# Altium schematic sheet Sheet1.SchDoc
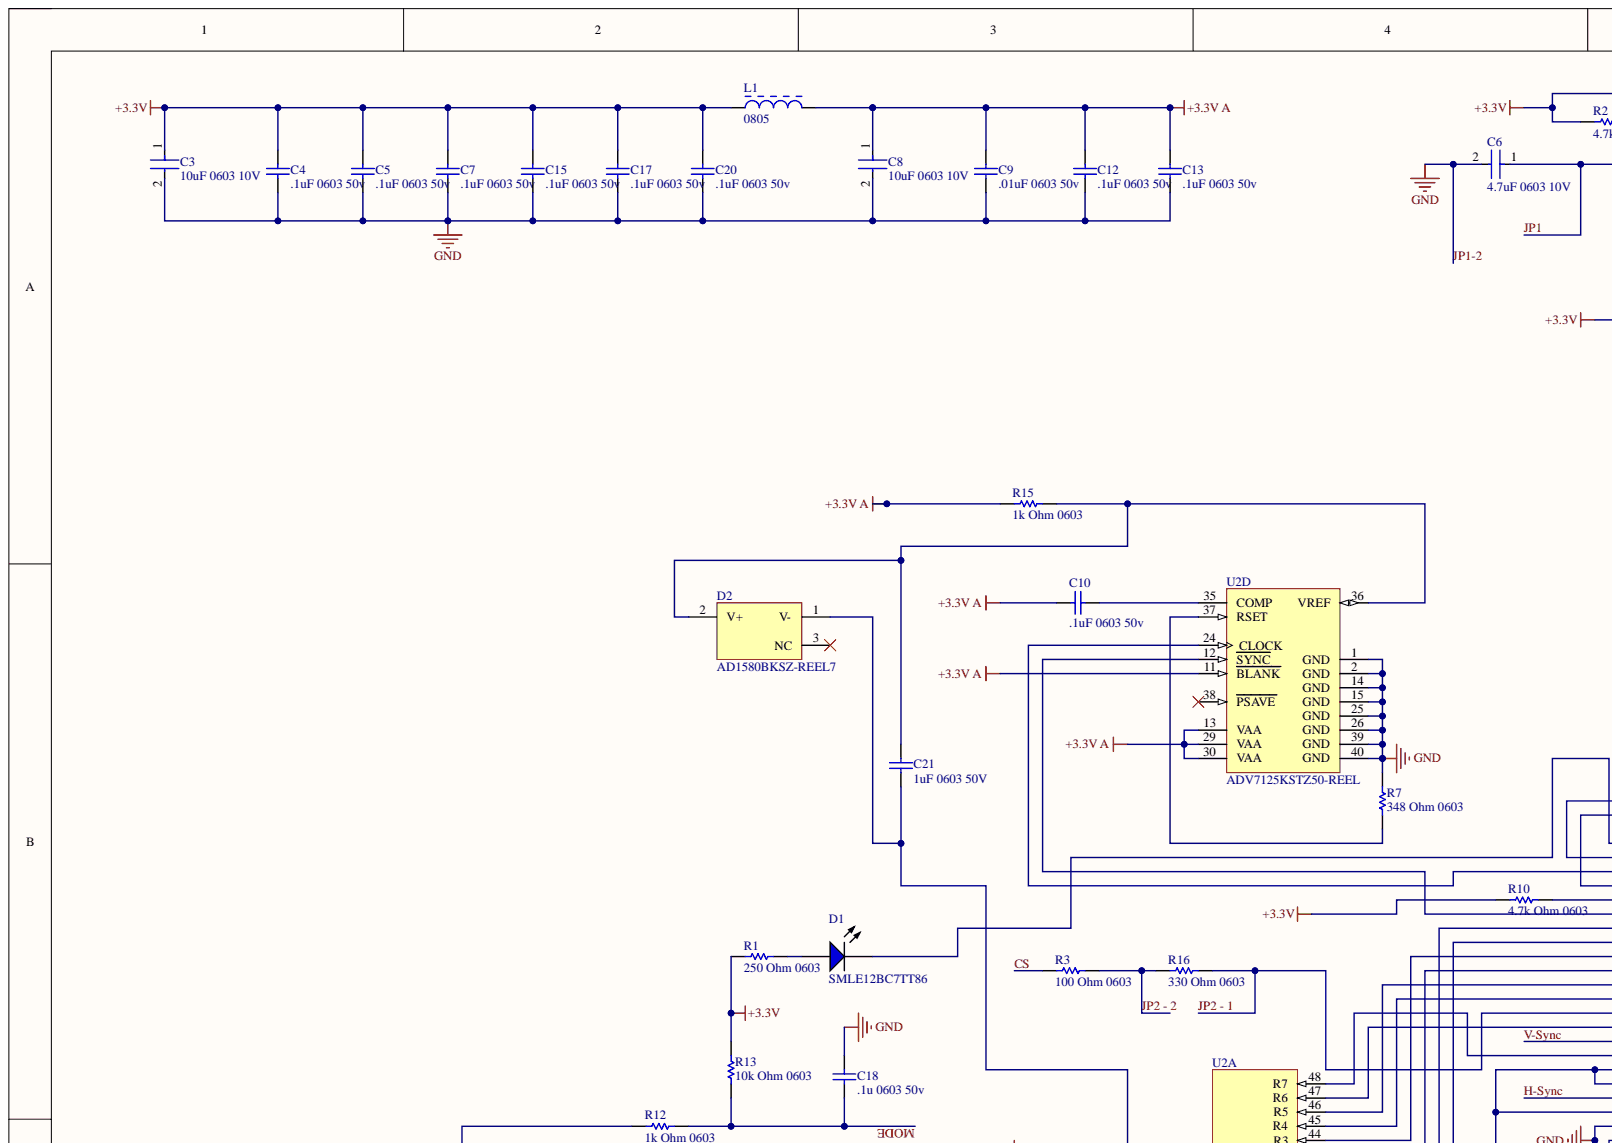
[diagram p0: Sheet1.SchDoc, top left]
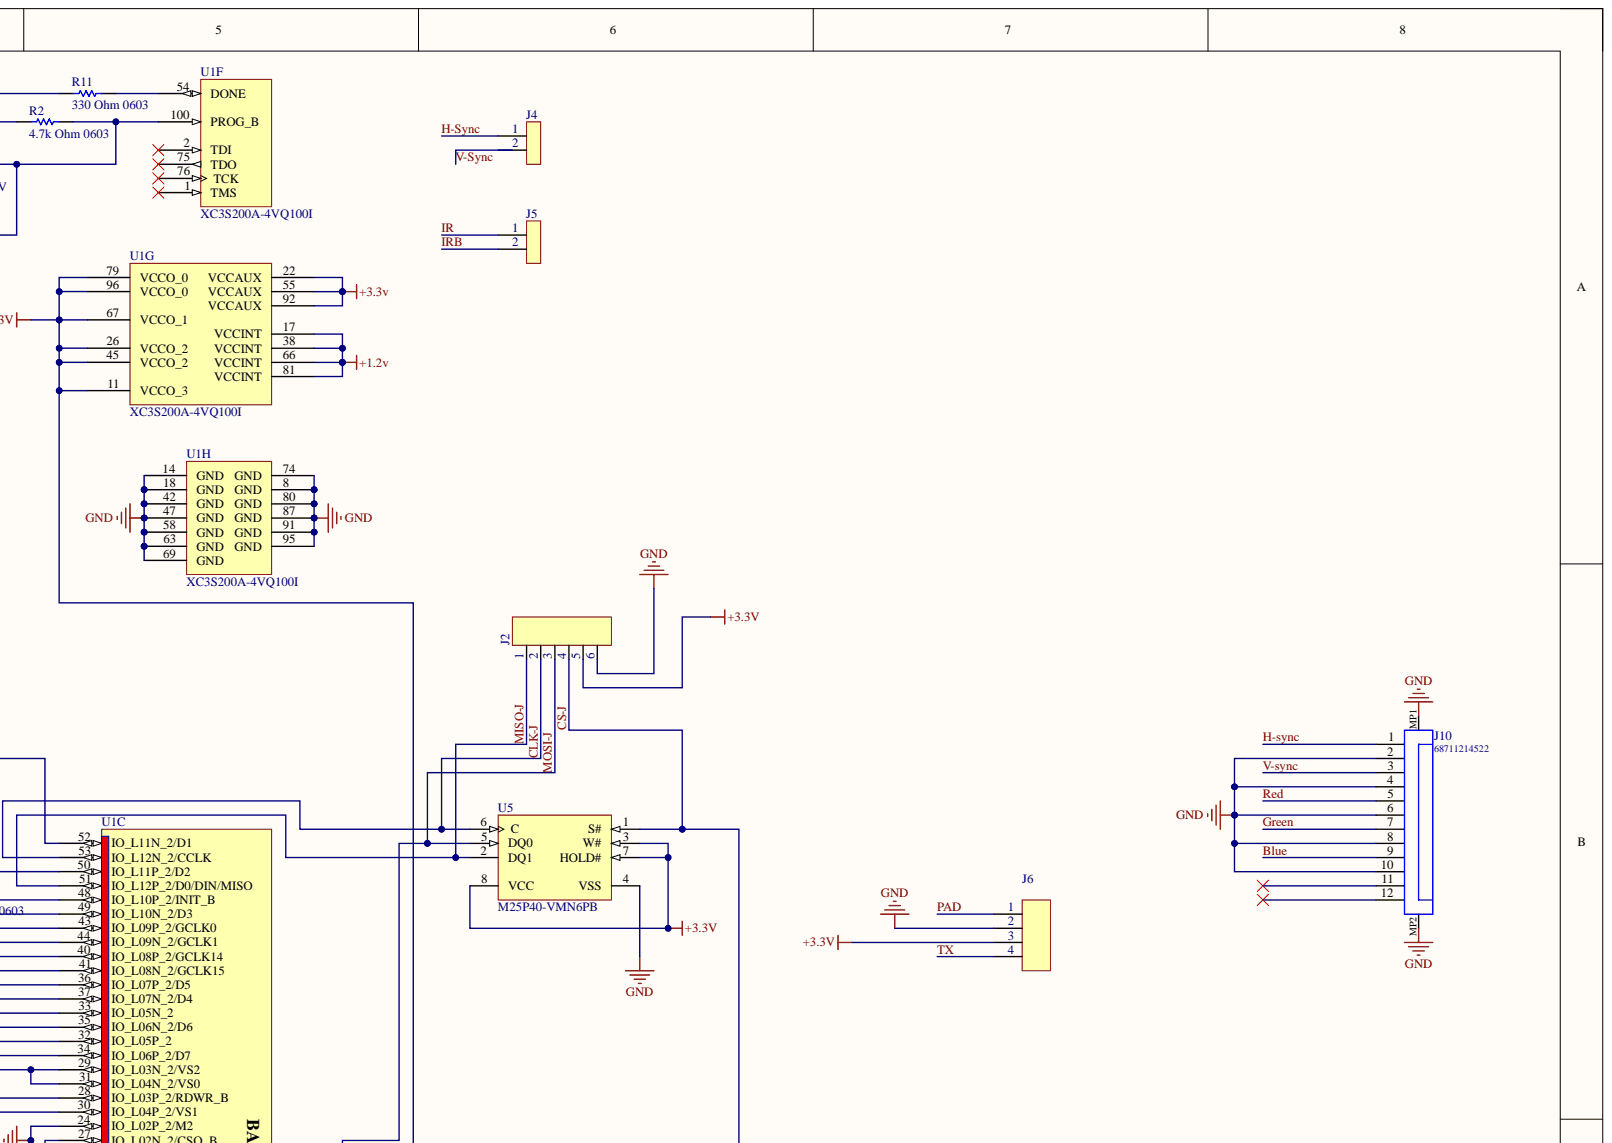
[diagram p1: Sheet1.SchDoc, top right]
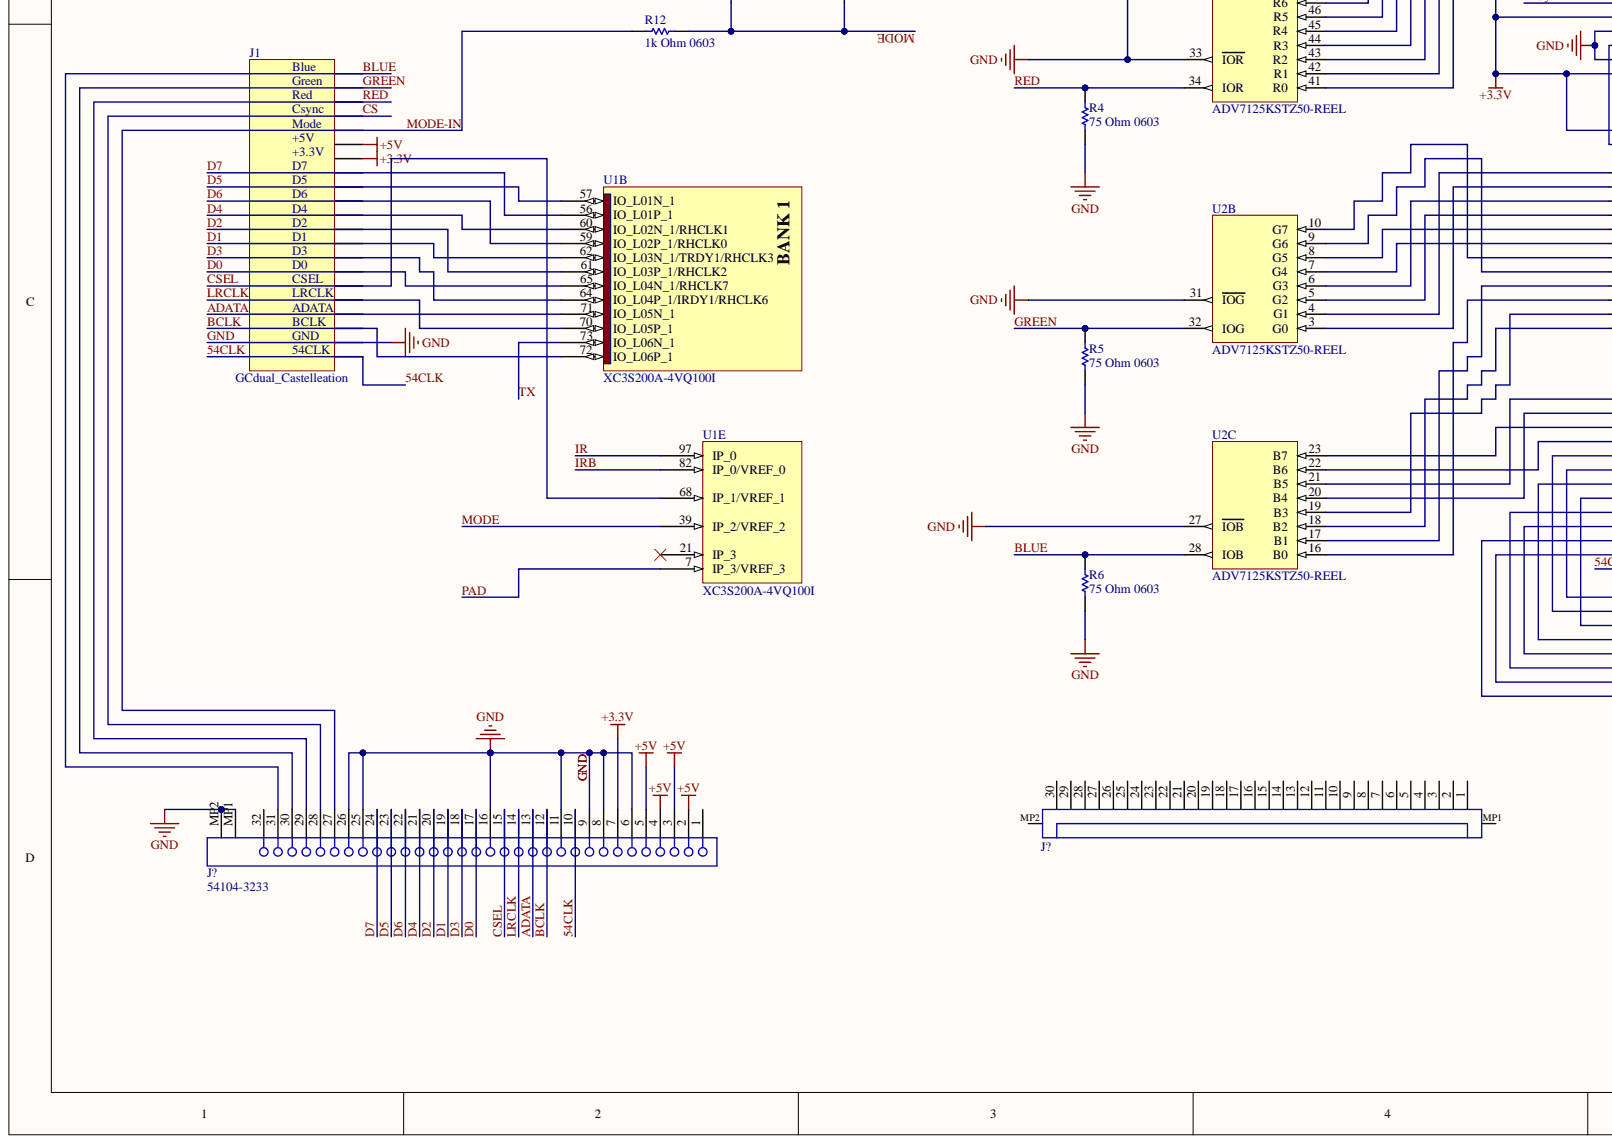
[diagram p2: Sheet1.SchDoc, bottom left]
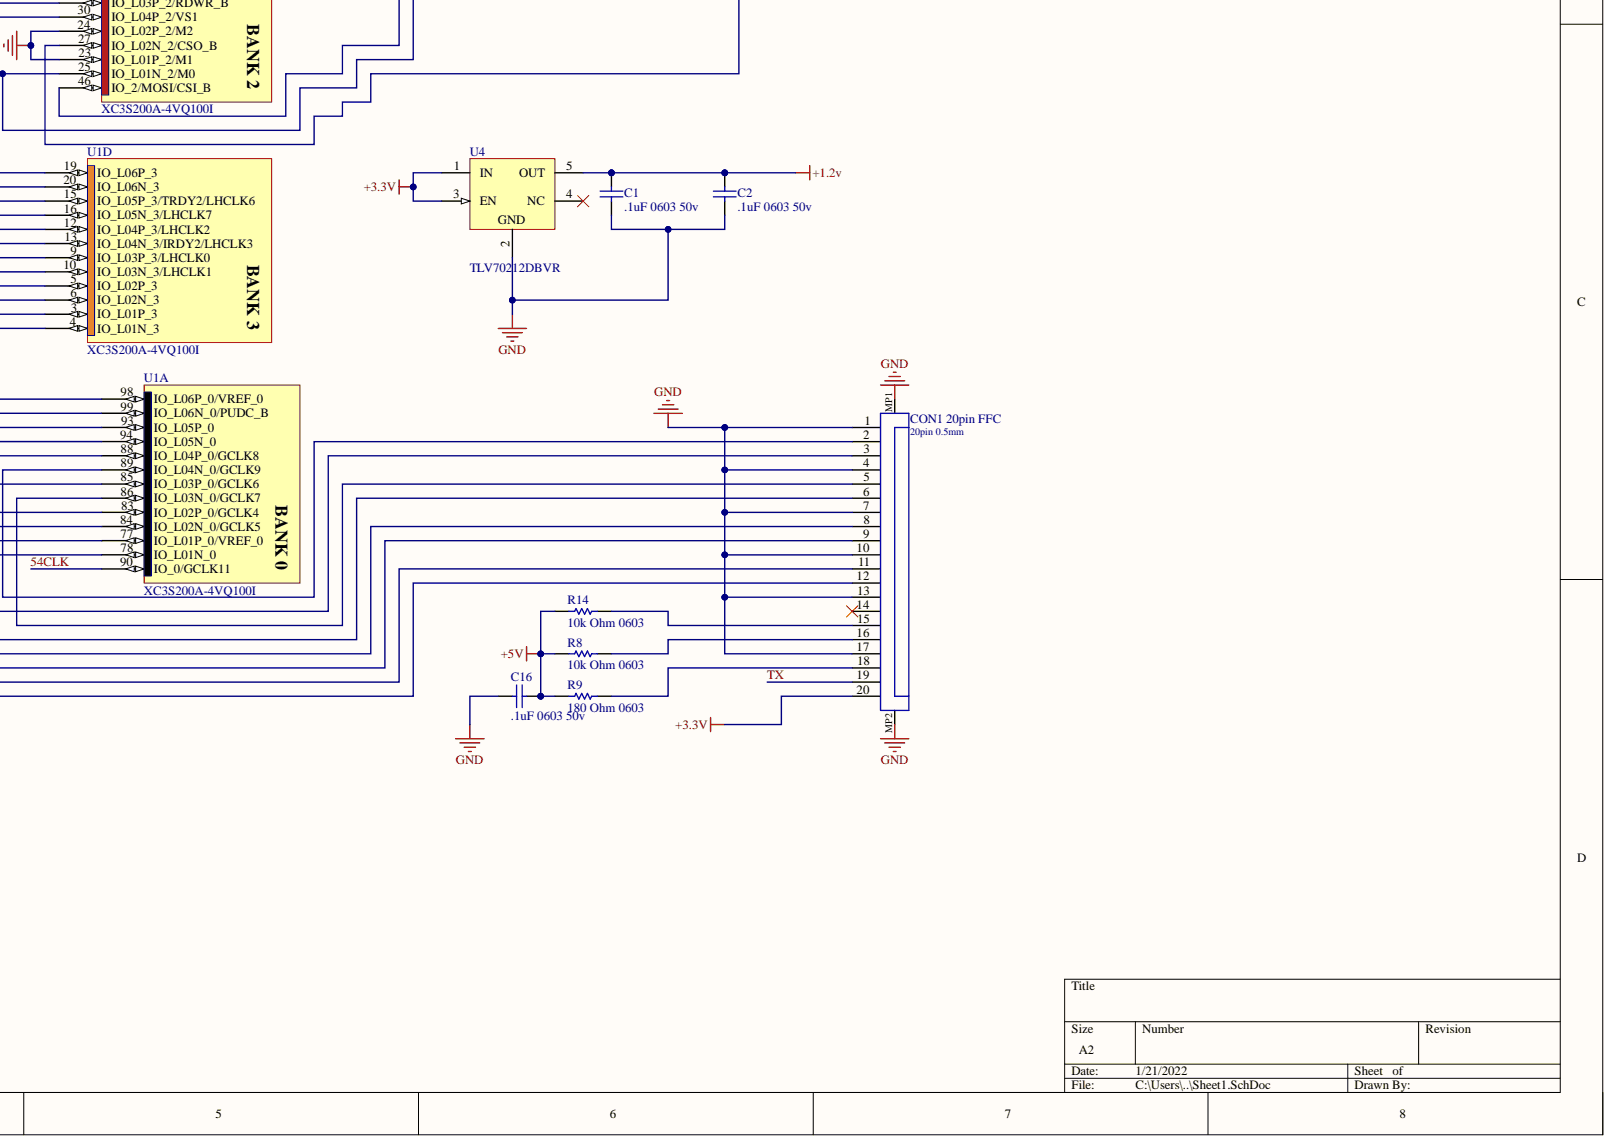
[diagram p3: Sheet1.SchDoc, bottom right]

## Parts
C1  .1uF 0603 50v  [CAPC1608X90X35LL15T15]
C2  .1uF 0603 50v  [CAPC1608X90X35LL15T15]
C3  10uF 0603 10V  value 10uF  Capacitance: 10uF  [FP-C1608-080-MFG]
C4  .1uF 0603 50v  [CAPC1608X90X35LL15T15]
C5  .1uF 0603 50v  [CAPC1608X90X35LL15T15]
C6  4.7uF 0603 10V  Capacitance: 4.7uF  Case Code (Imperial): 0603  Voltage Rating: 10V  [FP-CL10-IPC_C]
C7  .1uF 0603 50v  [CAPC1608X90X35LL15T15]
C8  10uF 0603 10V  value 10uF  Capacitance: 10uF  [FP-C1608-080-MFG]
C9  .01uF 0603 50v  Case Code (Imperial): 0603  [CAPC1608X90X35ML10T15]
C10  .1uF 0603 50v  [CAPC1608X90X35LL15T15]
C12  .1uF 0603 50v  [CAPC1608X90X35LL15T15]
C13  .1uF 0603 50v  [CAPC1608X90X35LL15T15]
C15  .1uF 0603 50v  [CAPC1608X90X35LL15T15]
C16  .1uF 0603 50v  [CAPC1608X90X35LL15T15]
C17  .1uF 0603 50v  [CAPC1608X90X35LL15T15]
C18  .1u 0603 50v  [CAPC1608X90X35LL15T15]
C20  .1uF 0603 50v  [CAPC1608X90X35LL15T15]
C21  1uF 0603 50V  Package Reference: 0603  [CAPC1608X90X20LL10]
CON1_20pin_FFC  20pin 0.5mm  Pitch: 0.5mm  [68712014022]
D1  SMLE12BC7TT86  [ROHM-SMLE12BC7TT86_V]
D2  AD1580BKSZ-REEL7  [ADI-KS-3_N]
J10  68711214522  [68711214522]
J?  54104-3233  [MOLX-54104-3233]
J?  (no value)  [687130149022]
L1  0805  [MURA-BLM21-H1-CHIP-2_V]
R1  250 Ohm 0603  [RESC1608X55X25ML10T15]
R2  4.7k Ohm 0603  Case Code - in: 0603  [RESC1608X55X25LL10T15]
R3  100 Ohm 0603  PackageReference: 0603  [RESC1609X50X30ML8T20]
R4  75 Ohm 0603  PackageReference: 0603  [RESC1609X50X30ML8T20]
R5  75 Ohm 0603  PackageReference: 0603  [RESC1609X50X30ML8T20]
R6  75 Ohm 0603  PackageReference: 0603  [RESC1609X50X30ML8T20]
R7  348 Ohm 0603  [RESC1609X50X30ML8T20]
R8  10k Ohm 0603  PackageReference: 0603  [RESC1609X50X30ML8T20]
R9  180 Ohm 0603  PackageReference: 0603  [RESC1609X50X30ML8T20]
R10  4.7k Ohm 0603  Case Code - in: 0603  [RESC1608X55X25LL10T15]
R11  330 Ohm 0603  PackageReference: 0603  [RESC1609X50X30ML8T20]
R12  1k Ohm 0603  PackageReference: 0603  [RESC1609X50X30ML8T20]
R13  10k Ohm 0603  [RESC1609X50X30ML8T20]
R14  10k Ohm 0603  PackageReference: 0603  [RESC1609X50X30ML8T20]
R15  1k Ohm 0603  PackageReference: 0603  [RESC1609X50X30ML8T20]
R16  330 Ohm 0603  PackageReference: 0603  [RESC1609X50X30ML8T20]
U1  XC3S200A-4VQ100I  [VQ100_M]  (parts A,B,C,D,E,F,G,H)
U2  ADV7125KSTZ50-REEL  [ST-48_L]  (parts A,B,C,D)
U4  TLV70212DBVR  [DBV0005A_N]
U5  M25P40-VMN6PB  [MICT-MN-8_N]

## Nets
+1.2v: C1.2 C2.2 U1.17(VCCINT) U1.38(VCCINT) U1.66(VCCINT) U1.81(VCCINT) U4.5(OUT)
+3.3v: C3.1 C4.1 C5.2 C7.2 C15.1 C17.2 C20.2 CON1_20pin_FFC.20 J?.7 L1.1 R1.1 R2.1 R10.1 R11.1 R13.2 U1.11(VCCO_3) U1.22(VCCAUX) U1.25(IO_L01N_2/M0) U1.26(VCCO_2) U1.29(IO_L03N_2/VS2) U1.30(IO_L04P_2/VS1) U1.31(IO_L04N_2/VS0) U1.45(VCCO_2) U1.55(VCCAUX) U1.67(VCCO_1) U1.79(VCCO_0) U1.92(VCCAUX) U1.96(VCCO_0) U4.1(IN) U4.3(EN) U5.3(W#) U5.7(HOLD#) U5.8(VCC)
+3.3V A: C8.1 C9.2 C10.1 C12.2 C13.2 L1.2 R15.1 U2.11(BLANK) U2.13(VAA) U2.29(VAA) U2.30(VAA)
+5V: C16.1 J?.2 J?.3 J?.4 J?.5 R8.1 R9.1 R14.1
54CLK: J?.10 U1.90(IO_0/GCLK11)
ADATA: J?.13 U1.71(IO_L05N_1)
BCLK: J?.12 U1.72(IO_L06P_1)
Blue: J10.9 J?.31 R6.2 U2.28(IOB)
CLK-J: U1.53(IO_L12N_2/CCLK) U5.6(C)
CS: J?.28 R3.1
CS-J: U1.27(IO_L02N_2/CSO_B) U5.1(S#)
CSEL: J?.15 U1.68(IP_1/VREF_1)
D0: J?.17 U1.65(IO_L04N_1/RHCLK7)
D1: J?.19 U1.62(IO_L03N_1/TRDY1/RHCLK3)
D2: J?.20 U1.61(IO_L03P_1/RHCLK2)
D3: J?.18 U1.64(IO_L04P_1/IRDY1/RHCLK6)
D4: J?.21 U1.60(IO_L02N_1/RHCLK1)
D5: J?.23 U1.57(IO_L01N_1)
D6: J?.22 U1.59(IO_L02P_1/RHCLK0)
D7: J?.24 U1.56(IO_L01P_1)
GND: C1.1 C2.1 C3.2 C4.2 C5.1 C6.2 C7.1 C8.2 C9.1 C12.1 C13.1 C15.2 C16.2 C17.1 C18.2 C20.1 C21.1 CON1_20pin_FFC.1 CON1_20pin_FFC.4 CON1_20pin_FFC.7 CON1_20pin_FFC.10 CON1_20pin_FFC.13 CON1_20pin_FFC.17 CON1_20pin_FFC.MP1 CON1_20pin_FFC.MP2 D2.1 J10.2 J10.4 J10.6 J10.8 J10.10 J10.MP1 J10.MP2 J?.6 J?.8 J?.9 J?.11 J?.16 J?.25 J?.26 J?.MP1 J?.MP2 R4.1 R5.2 R6.1 R7.2 U1.8(GND) U1.14(GND) U1.18(GND) U1.23(IO_L01P_2/M1) U1.24(IO_L02P_2/M2) U1.42(GND) U1.47(GND) U1.58(GND) U1.63(GND) U1.69(GND) U1.74(GND) U1.80(GND) U1.87(GND) U1.91(GND) U1.95(GND) U2.1(GND) U2.2(GND) U2.14(GND) U2.15(GND) U2.25(GND) U2.26(GND) U2.27(IOB) U2.31(IOG) U2.33(IOR) U2.39(GND) U2.40(GND) U4.2 U5.4(VSS)
Green: J10.7 J?.30 R5.1 U2.32(IOG)
H-sync: J10.1 U1.28(IO_L03P_2/RDWR_B)
IR: U1.97(IP_0)
IRB: U1.82(IP_0/VREF_0)
JP1: C6.1 R2.2 U1.100(PROG_B)
JP2 - 1: R16.2 U1.33(IO_L05N_2)
JP2 - 2: R3.2 R16.1
LRCLK: J?.14 U1.70(IO_L05P_1)
MISO-J: U1.51(IO_L12P_2/D0/DIN/MISO) U5.2(DQ1)
MODE: C18.1 R12.2 R13.1 U1.39(IP_2/VREF_2)
MODE-IN: J?.27 R12.1
MOSI-J: U1.46(IO_2/MOSI/CSI_B) U5.5(DQ0)
PAD: U1.7(IP_3/VREF_3)
Red: J10.5 J?.29 R4.2 U2.34(IOR)
TX: CON1_20pin_FFC.19 U1.73(IO_L06N_1)
V-sync: J10.3 U1.32(IO_L05P_2)
NetC10_2: C10.2 U2.35(COMP)
NetC21_2: C21.2 D2.2 R15.2 U2.36(VREF)
NetCON1 20pin FFC_2: CON1_20pin_FFC.2 U1.89(IO_L04N_0/GCLK9)
NetCON1 20pin FFC_3: CON1_20pin_FFC.3 U1.88(IO_L04P_0/GCLK8)
NetCON1 20pin FFC_5: CON1_20pin_FFC.5 U1.86(IO_L03N_0/GCLK7)
NetCON1 20pin FFC_6: CON1_20pin_FFC.6 U1.85(IO_L03P_0/GCLK6)
NetCON1 20pin FFC_8: CON1_20pin_FFC.8 U1.84(IO_L02N_0/GCLK5)
NetCON1 20pin FFC_9: CON1_20pin_FFC.9 U1.83(IO_L02P_0/GCLK4)
NetCON1 20pin FFC_11: CON1_20pin_FFC.11 U1.78(IO_L01N_0)
NetCON1 20pin FFC_12: CON1_20pin_FFC.12 U1.77(IO_L01P_0/VREF_0)
NetCON1 20pin FFC_15: CON1_20pin_FFC.15 R14.2
NetCON1 20pin FFC_16: CON1_20pin_FFC.16 R8.2
NetCON1 20pin FFC_18: CON1_20pin_FFC.18 R9.2
NetD1_1: D1.1 R1.2
NetD1_2: D1.2 U1.52(IO_L11N_2/D1)
NetR7_1: R7.1 U2.37(RSET)
NetR10_2: R10.2 U1.48(IO_L10P_2/INIT_B)
NetR11_2: R11.2 U1.54(DONE)
NetU1_3: U1.3(IO_L01P_3) U2.19(B3)
NetU1_4: U1.4(IO_L01N_3) U2.18(B2)
NetU1_5: U1.5(IO_L02P_3) U2.17(B1)
NetU1_6: U1.6(IO_L02N_3) U2.16(B0)
NetU1_9: U1.9(IO_L03P_3/LHCLK0) U2.10(G7)
NetU1_10: U1.10(IO_L03N_3/LHCLK1) U2.9(G6)
NetU1_12: U1.12(IO_L04P_3/LHCLK2) U2.8(G5)
NetU1_13: U1.13(IO_L04N_3/IRDY2/LHCLK3) U2.7(G4)
NetU1_15: U1.15(IO_L05P_3/TRDY2/LHCLK6) U2.6(G3)
NetU1_16: U1.16(IO_L05N_3/LHCLK7) U2.5(G2)
NetU1_19: U1.19(IO_L06P_3) U2.4(G1)
NetU1_20: U1.20(IO_L06N_3) U2.3(G0)
NetU1_34: U1.34(IO_L06P_2/D7) U2.48(R7)
NetU1_35: U1.35(IO_L06N_2/D6) U2.47(R6)
NetU1_36: U1.36(IO_L07P_2/D5) U2.46(R5)
NetU1_37: U1.37(IO_L07N_2/D4) U2.45(R4)
NetU1_40: U1.40(IO_L08P_2/GCLK14) U2.44(R3)
NetU1_41: U1.41(IO_L08N_2/GCLK15) U2.43(R2)
NetU1_43: U1.43(IO_L09P_2/GCLK0) U2.42(R1)
NetU1_44: U1.44(IO_L09N_2/GCLK1) U2.41(R0)
NetU1_49: U1.49(IO_L10N_2/D3) U2.12(SYNC)
NetU1_50: U1.50(IO_L11P_2/D2) U2.24(CLOCK)
NetU1_93: U1.93(IO_L05P_0) U2.23(B7)
NetU1_94: U1.94(IO_L05N_0) U2.22(B6)
NetU1_98: U1.98(IO_L06P_0/VREF_0) U2.21(B5)
NetU1_99: U1.99(IO_L06N_0/PUDC_B) U2.20(B4)

Not connected: CON1_20pin_FFC 14; D2 3; J10 11 12; J? 1 32; U1 1(TMS) 2(TDI) 21(IP_3) 75(TDO) 76(TCK); U2 38(PSAVE); U4 4(NC)

## Library data of the parts
C1 C2 C4 C5 C7 C10 C12 C13 C15-C18 C20 = CMP-2006-04532-1: Manufacturer: AVX Interconnect / Elco; Part Number: 0603YD105KAT2A; Component Kind: Standard; Library Name: Altium Content Vault
C3 C8 = CMP-2000-04979-2: CAP CER 10UF 10V X5R 0603; Manufacturer: TDK; Manufacturer Part Number: C1608X5R1A106K080AC; Material: Ceramic; Temperature Coefficient: 15%; Tolerance: 10%; Automotive: No; Category: Cap; Component Kind: Standard; ComponentLink2Description: Datasheet; ComponentLink2URL: https://product.tdk.com/info/en/documents/chara_sheet/C1608X5R1A106K080AC.pdf; Device Class L1: Passive Components; Device Class L2: Capacitors; Device Class L3: Ceramic Capacitors; Digikey Description: CAP CER 10UF 10V X5R 0603; Footprint Url: https://product.tdk.com/info/en/catalog/spec/mlccspec_commercial_general_midvoltage_en.pdf; Height: 0.9mm; Ipc Land Pattern Name: CAPC16080X80; Lead Free: Yes; Library Name: Altium Content Vault; Mouser Description: CAP CER 10UF 10V X5R 0603; Octopart: https://octopart.com/c1608x5r1a106k080ac-tdk-26013468; Package: C1608-080; RoHS: TRUE; Temperature: -55°C to +85°C; Temperature Characteristic: X5R; Temperature Range High: +85°C; Temperature Range Low: -55°C; Voltage: 10V; Voltage Rating: 10V
C6 = CMP-13271-003017-1: Cap Ceramic 4.7uF 10V X5R ±10% Pad SMD 0603 +85°C T/R; Case Code (Metric): 1608; Case/Package: 0603; Component Kind: Standard; Contact Plating: Tin; Depth: 0.8mm; Dielectric: X5R; Height: 0.8mm; Length: 1.6mm; Library Name: Altium Content Vault; Max Operating Temperature: 85°C; Min Operating Temperature: -55°C; Mount: Surface Mount; Package Quantity: 1; Packaging: Tape and Reel; Radiation Hardening: No; Thickness: 0.035inch; Tolerance: 10%; Width: 0.031inch
C9 = CMP-2100-03642-1: Chip Capacitor, 10 nF, +/- 10%, 50 V, -55 to 125 degC, 0603 (1608 Metric), RoHS, Tape and Reel; Manufacturer: Murata; Capacitance: 10nF; Dielectric: X7R; Dielectric Material: Ceramic; Insulation Resistance: 10GR; Case Code (Metric): 1608; Case/Package: 0603; Component Kind: Standard; Datasheet URL: http://search.murata.co.jp/Ceramy/image/img/A01X/G101/ENG/GRM188R71H103KA01-01.pdf; Datasheet Version: 42401.0; Depth: 0.8mm; Height: 0.8mm; Lead Free: LeadFree; Length: 1.6mm; Library Name: Altium Content Vault; Manufacturer URL: http://www.murata.com/; Material: Ceramic; Max Operating Temperature: 125degC; Min Operating Temperature: -55degC; Mount: SurfaceMount; Number of Pins: 2; Package Description: 2-Pin Surface Mount Device, Body 1.6 x 0.8 mm; Package Quantity: 1; Package Reference: 0603; Packaging: TapeandReel; REACH SVHC: Unknown; RoHSCompliant: True; Termination: SMD/SMT; Thickness: 0.035inch; Tolerance: 10%; Voltage: 50V; Voltage Rating: 50V; Voltage Rating (DC): 50V; Width: 0.031inch
C21 = CMP-2000-06025-1: Chip Capacitor, 1 uF, +/- 10%, 50 V, -55 to 85 degC, 0603 (1608 Metric), RoHS, Tape and Reel; Manufacturer: TDK; PCN Part Number: MLCCPartNumberChange30/Nov/2012; Capacitance: 1µF; Design Resources: 20WNon-IsolatedBuckLEDDriverUsingPLLNK460KG; Online Catalog: CSeries,GeneralPurpose; Other Names: 445-7468-6; Product Training Modules: CSeriesGeneralApplicationsSEAT,CCV,andTVCLDesignToolsGuidetoReplacinganElectrolyticCapacitorwithanMLCC; Temperature Coefficient: X5R; Applications: GeneralPurpose; Category: Capacitors; Component Kind: Standard; Datasheet URL: https://product.tdk.com/info/en/catalog/datasheets/mlcc_commercial_general_en.pdf; Datasheet Version: 08/2016; Family: CeramicCapacitors; Features: -; Height - Seated (Max): -; Lead Spacing: -; Lead Style: -; Library Name: Altium Content Vault; Manufacturer URL: http://www.tdk.com/; Mounting Technology: SurfaceMount; Mounting Type: SurfaceMount,MLCC; Operating Temperature: -55°C~85°C; Package / Case: 0603(1608Metric); Package Description: 2-Pin Surface Mount Device, Body 1.6 x 0.8 mm; Packaging: Digi-Reel®; Ratings: -; RoHS Information: RoHSCertificate-MLCC; Series: C; Size / Dimension: 0.063"Lx0.031"W(1.60mmx0.80mm); Standard Package: 1; Thickness (Max): 0.035"(0.90mm); Tolerance: ±10%; Voltage - Rated: 50V
CON1_20pin_FFC = CMP-1502-01556-1: SMT ZIF Connectors WR-FPC, Horizontal, Top Contact, pitch 0.5 mm, 20 pins; Manufacturer: Wurth Elektronik; Contact Resistance: 20mOhm; Pin Number: 20; Rated Current: 0.5A; Withstanding Voltage: 250VAC; Working Voltage: 50VAC; Component Kind: Standard; Contact Design: TopContact; Datasheet URL: https://katalog.we-online.de/em/datasheet/68712014022.pdf; Library Name: Altium Content Vault; Manufacturer URL: http://www.we-online.de; Mounting Technology: SMT; Operating Temperature: -25to85degC; Orientation: Horizontal; Package Reference: 68712014022; Packaging: TapeandReel; Part Number: 68712014022; Publisher: WurthElektronik
D1 = CMP-2000-07118-1: Blue LED, 2.9 V, 20 mA, -30 to 85 degC, 2-Pin SMD, RoHS, Tape and Reel; Manufacturer: Rohm; Forward (Drive) Current: 20mA; Forward Voltage: 2.9V; Intensity: 22mcd; Lens Type: Clear; Viewing Angle: 140°; Color: Blue; Component Kind: Standard; Datasheet URL: https://datasheet.ciiva.com/11773/0900766b80df3ad8-11773825.pdf; Datasheet Version: Rev. 2.0, 03/2010; Dimension: 1.6x0.8x0.36mm; Library Name: Altium Content Vault; Manufacturer URL: http://www.rohm.com/web/global/; Mounting Technology: SurfaceMount; Package Description: 2-Pin Surface Mount Device, Body 1.6 x 0.8 mm; Package Reference: 1608
D2 = CMP-0784-00003-1: 1.2 V Micropower, Precision Shunt Voltage Reference, 1.225 V Output, Industrial, 3-pin SOT23 (KS-3), Reel; Manufacturer: Analog Devices; Ref Out TC (ppm/C): 50; Vout: 1.225V; Component Kind: Standard; ComponentLink1Description: Manufacturer URL; ComponentLink1URL: http://www.analog.com/en/index.html; ComponentLink2Description: Datasheet; ComponentLink2URL: http://www.analog.com/static/imported-files/data_sheets/AD1580.pdf; ComponentLink3Description: Package Specification; ComponentLink3URL: http:/www.analog.com/static/imported-files/packages/PKG_PDF/SC70(KS)/KS_3.pdf; ComponentLink4Description: URL Link1; ComponentLink4URL: Product URL:http://www.analog.com/en/special-linear-functions/voltage-references/ad1580/products/product.html; DatasheetVersion: Rev. F; Library Name: Altium Content Vault; Mounting Technology: Surface Mount; Operating Temp Range: -40 to 85 degC; PackageDescription: 3-Pin Thin Shrink Small Outline Transistor Package, Body 2 x 1.25 mm, Pitch 0.65 mm; PackageReference: KS-3; PackageVersion: 072809-A, 07/2009; Packing: Reel; PartNumber: AD1580BKSZ-REEL7
J10 = CMP-1502-01530-1: SMT ZIF Connectors WR-FPC, Horizontal, Bottom Contact, pitch 0.5 mm, 12 pins; Manufacturer: Wurth Elektronik; Contact Resistance: 20mOhm; Pin Number: 12; Pitch: 0.5mm; Rated Current: 0.5A; Withstanding Voltage: 250VAC; Working Voltage: 50VAC; Component Kind: Standard; Contact Design: BottomContact; Datasheet URL: https://katalog.we-online.de/em/datasheet/68711214522.pdf; Library Name: Altium Content Vault; Manufacturer URL: http://www.we-online.de; Mounting Technology: SMT; Operating Temperature: -25to85degC; Orientation: Horizontal; Package Reference: 68711214522; Packaging: TapeandReel; Part Number: 68711214522; Publisher: WurthElektronik
J? = CMP-1241-00497-1: 0.5 mm Pitch Easy-On(TM) Type FPC Connector, 2.0 mm Mated Height, Right Angle, ZIF, SMT, Top Contact, 32 Circuits, -40 to 85 degC, ELV and RoHS Compliant, Tape and Reel; Manufacturer: Molex; Circuits (Loaded): 32; Current (Max per Contact): 0.5A; Durability (mating cycles max): 20; Entry Angle: 90degrees Angle; Mated Height: 2.00mm; Material - Metal: Phosphor Bronze; Material - Plating Mating: Silver, Tin-Bismuth; PCB Locator: No; PCB Retention: None; Pitch - Mating Interface: 0.50mm; Polarized to PCB: Yes; Voltage (Max): 50V; Component Kind: Standard; ComponentLink1Description: Manufacturer URL; ComponentLink1URL: http://www.molex.com/molex/index.jsp; ComponentLink2Description: Datasheet; ComponentLink2URL: http://www.molex.com/webdocs/datasheets/pdf/en-us/0541043233_FFC_FPC_CONNECTORS.pdf; ComponentLink3Description: Package Specification; ComponentLink3URL: http://www.molex.com/pdm_docs/sd/541043233_sd.pdf; Contact Position: Top; Library Name: Altium Content Vault; Material - Plating Termination: Silver, Tin-Bismuth; Mounting Technology: Surface Mount; Number of Rows: 1; Orientation: Right Angle; PackageDescription: 32-Lead FPC Connector, Pitch 0.5 mm; PackageReference: 54104-3233; PackageVersion: Rev. C, 04/2014; Packing: Tape and Reel; PartNumber: 54104-3233; Pitch - Termination Interface: 0.50mm; RoHS: TRUE; Stackable: No
J? = CMP-1502-01636-1: SMT ZIF Connectors Hinge Type WR-FPC, Horizontal, Bottom Contact, pitch 0.5 mm, 30 pins; Manufacturer: Wurth Elektronik; Contact Resistance: 50mOhm; Pin Number: 30; Pitch: 0.5mm; Rated Current: 0.5A; Withstanding Voltage: 150VAC; Working Voltage: 50VAC; Component Kind: Standard; Contact Design: BottomContact; Datasheet URL: https://katalog.we-online.de/em/datasheet/687130149022.pdf; Library Name: Altium Content Vault; Manufacturer URL: http://www.we-online.de; Mounting Technology: SMT; Operating Temperature: -40to105degC; Orientation: Horizontal; Package Reference: 687130149022; Packaging: TapeandReel; Part Number: 687130149022; Publisher: WurthElektronik
L1 = CMP-0686-00716-2: Chip Ferrite Bead for General Signal lines, 120 Ohm, 800 mA, -55 to 125 degC, 2 x 1.25 x 1.05 mm SMD, Tape and Reel; Manufacturer: Murata; PartNumber: BLM21AG121SN1D; DC Resistance: 0.1 Ohm Max.; Impedance (at 100 MHz): 120 Ohm +/- 25%; Rated Current: 800 mA; Component Kind: Standard; ComponentLink1Description: Manufacturer URL; ComponentLink1URL: http://www.murata.com/; ComponentLink2Description: Datasheet; ComponentLink2URL: http://www.murata.com/products/catalog/pdf/c31e.pdf; ComponentLink3Description: Package Specification; ComponentLink3URL: http://www.murata.com/products/catalog/pdf/c31e.pdf; DatasheetVersion: 08/2013; Library Name: Altium Content Vault; Mounting Technology: Surface Mount; Operating Temperature Range: -55 to 125 degC; PackageDescription: 2-Pin Chip, Body 2 x 1.25 mm; PackageReference: BLM21_H1050-2; Packing: Tape and Reel
R1 = CMP-2100-03667-1: Chip Resistor, 0 Ohm, +/- 5%, 0.1 W, -55 to 155 degC, 0603 (1608 Metric), RoHS, Tape and Reel; Manufacturer: Yageo; Power Rating: 100mW; Resistance: 0mOhm; Temperature Coefficient: 200ppm/degreesC; Voltage Rating: 50V; Case Code (Imperial): 0603; Case Code (Metric): 1608; Case/Package: 0603; Component Kind: Standard; Composition: ThickFilm; Contact Plating: Tin; Datasheet URL: http://www.yageo.com/NewPortal/yageodocoutput?fileName=/pdf/R-Chip/PYu-RC_Group_51_RoHS_L_5.pdf; Datasheet Version: Rev. 5, 10/2016; Depth: 0.8mm; Height: 0.45mm; Lead Free: LeadFree; Length: 1.6mm; Library Name: Altium Content Vault; Manufacturer URL: http://www.yageo.com/; Max Operating Temperature: 155degC; Military Standard: Not; Min Operating Temperature: -55degC; Number of Pins: 2; Package Description: 2-Pin Surface Mount Device, Body 1.6 x 0.8 mm; Package Quantity: 5000; Package Reference: 0603; Packaging: TapeandReel; Radiation Hardening: No; REACH SVHC: NoSVHC; RoHSCompliant: true; Termination: SMD/SMT; Tolerance: 5%; Voltage Rating (DC): 50V; Width: 0.8mm
R2 R10 = CMP-2000-06942-1: Chip Resistor, 4.7 KOhm, +/- 1%, 0.1 W, -55 to 155 degC, 0603 (1608 Metric), RoHS, Tape and Reel; Manufacturer: Yageo; Power Rating: 100mW; Resistance: 4.7kOhms; Temperature Coefficient: 100PPM/C; Voltage Rating: 50V; Case Code - mm: 1608; Component Kind: Standard; Datasheet URL: http://www.yageo.com/documents/recent/PYu-RC_Group_51_RoHS_L_7.pdf; Datasheet Version: Rev. 7, 05/2017; Library Name: Altium Content Vault; Manufacturer URL: http://www.yageo.com/; Mounting Technology: SurfaceMount; Operating Temperature Range: -55Cto+155C; Package Description: 2-Pin Surface Mount Device, Body 1.6 x 0.8 mm; Package Reference: 0603; Packaging: Reel; Product: ThickFilmResistorsGeneralPurpose; Series: RC; Termination Style: SMD/SMT; Tolerance: 1%
R3-R9 R11-R16 = CMP-2000-03824-5: Chip Resistor, 100 Ohm, +/- 1%, 100 mW,-55 to 155 degC, 0603 (1608 Metric), RoHS, Tape and Ree; Manufacturer: Vishay Semiconductor; Component Kind: Standard; ComponentLink1Description: Manufacturer URL; ComponentLink1URL: http://www.vishay.com/; ComponentLink2Description: Datasheet; ComponentLink2URL: http://www.vishay.com/docs/20035/dcrcwe3.pdf; DatasheetVersion: 06/2012; Library Name: Altium Content Vault; Mounting Technology: Surface Mount; PackageDescription: 2-Pin Surface Mount Device, Body 1.575 x 0.85 mm
  R7 R13: PackageReference: 0603
U1 = CMP-0049-00089-1: Spartan-3A I/O Optimized FPGA, 68 User I/Os, 100-Pin VQFP, Standard Performance, Industrial Grade; Code_JEDEC: MS-026-AED; Component Kind: Standard; DatasheetVersion: ver1.8, Mar-2009; Library Name: Altium Content Vault; PackageDescription: 100-Pin Very Thin Quad Flat Pack (VQ100); PackageReference: VQ100; PackageVersion: ver1.2.1, Feb-2007
U2 = CMP-0318-00720-2: 3 Channel 8-Bit CMOS High Speed Video Digital-to-Analog Converter, -40 to +85 degC, ST-48, Pb-Free, Reel; Manufacturer: Analog Devices; # DAC Outputs: 3; Max V+: 5.25V; Op Pwr Diss: 485mW; Resolution (Bits): 8; Single Supply: No; Component Kind: Standard; ComponentLink1Description: Manufacturer URL; ComponentLink1URL: http://www.analog.com/en/index.html; ComponentLink2Description: Datasheet; ComponentLink2URL: http://www.analog.com/static/imported-files/data_sheets/ADV7125.pdf; ComponentLink3Description: Package Specification; ComponentLink3URL: http://www.analog.com/static/imported-files/packages/PKG_PDF/LQFP%28ST%29/ST_48.pdf; ComponentLink4Description: Product URL; ComponentLink4URL: http://www.analog.com/en/digital-to-analog-converters/da-converters/adv7125/products/product.html; DatasheetVersion: Rev.C; Generic Part Number: ADV7125; Library Name: Altium Content Vault; Mounting Technology: Surface Mount; PackageDescription: 48-Pin Thin Quad Flat Pack 0.5 mm Pitch; PackageReference: ST-48; PackageVersion: revA; Packing: Reel; PartNumber: ADV7125KSTZ50-REEL; RevisionNote: Component URL fixed; RoHS: Y
U4 = CMP-0394-00516-2: Single Output LDO, 300 mA, Fixed 1.2 V Output, 2 to 5.5 V Input, with Low IQ, 5-pin SOT-23 (DBV), -40 to 125 degC, Green (RoHS & no Sb/Br); Manufacturer: Texas Instruments; Accuracy(%): 2; Fixed Output Options(V): 1.2; Iout(Max)(A): 0.3; Iq(Typ)(mA): 0.03; SubFamily: Single Channel LDO; Vdo(Typ)(mV): 220; Vin(Max)(V): 5.5; Code_JEDEC: MO-178; Component Kind: Standard; ComponentLink1Description: Manufacturer URL; ComponentLink1URL: http://www.ti.com/; ComponentLink2Description: Datasheet; ComponentLink2URL: http://www.ti.com/general/docs/lit/getliterature.tsp?genericPartNumber=TLV70212&fileType=pdf; ComponentLink3Description: Package Specification; ComponentLink3URL: http://www.ti.com/litv/pdf/mpds018i; DatasheetVersion: SLVSAG6B; Eco-Plan: Green (RoHS & no Sb/Br); Library Name: Altium Content Vault; Mounting Technology: Surface Mount; PackageDescription: 5-Pin Plastic Small Outline, Body 1.60 Ãƒâ€” 2.90 mm, Pitch 0.95 mm; PackageReference: DBV0005A; PackageVersion: 4073253-4/K; PartNumber: TLV70212DBVR; Vin(Min)(V): 2; Vout Adj(Max)(V): 1.2; Vout Adj(Min)(V): 1.2
U5 = CMP-0357-00075-1: 4 Mb (x1) Serial NOR Flash Memory, 75 MHz, 2.3 to 3.6 V, 8-pin SO8 Narrow (MN), Tube; Manufacturer: Micron; Part Family: M25PÂ; Density: 4MbÂ; Speed: 75 MHzÂ; Voltage: 2.3V-3.6VÂ; Applications: EmbeddedÂ; Component Kind: Standard; ComponentLink1Description: Manufacturer URL; ComponentLink1URL: http://www.micron.com/; ComponentLink2Description: Datasheet; ComponentLink2URL: http://www.micron.com/~/media/Documents/Products/Data%20Sheet/NOR%20Flash/Serial%20NOR/M25P/M25P40.pdf; ComponentLink3Description: Package Specification; ComponentLink3URL: http://www.micron.com/~/media/Documents/Products/Data%20Sheet/NOR%20Flash/Serial%20NOR/M25P/M25P05A.pdf; DatasheetVersion: Rev. Y; Library Name: Altium Content Vault; Mounting Technology: Surface Mount; Package: SO8 NarrowÂ; PackageDescription: 8-Pin SO Narrow, 3.9 X 4.9 mm, Pitch 1.27 mm; PackageReference: MICT-MN-8; PackageVersion: Rev 11; Part Status: ProductionÂ; PartNumber: M25P40-VMN6PB; RoHS: YesÂ; Type: Data StorageÂ; Width: x1Â
